# Altium schematic sheet wls2-norflash.SchDoc(wls2-norflash)
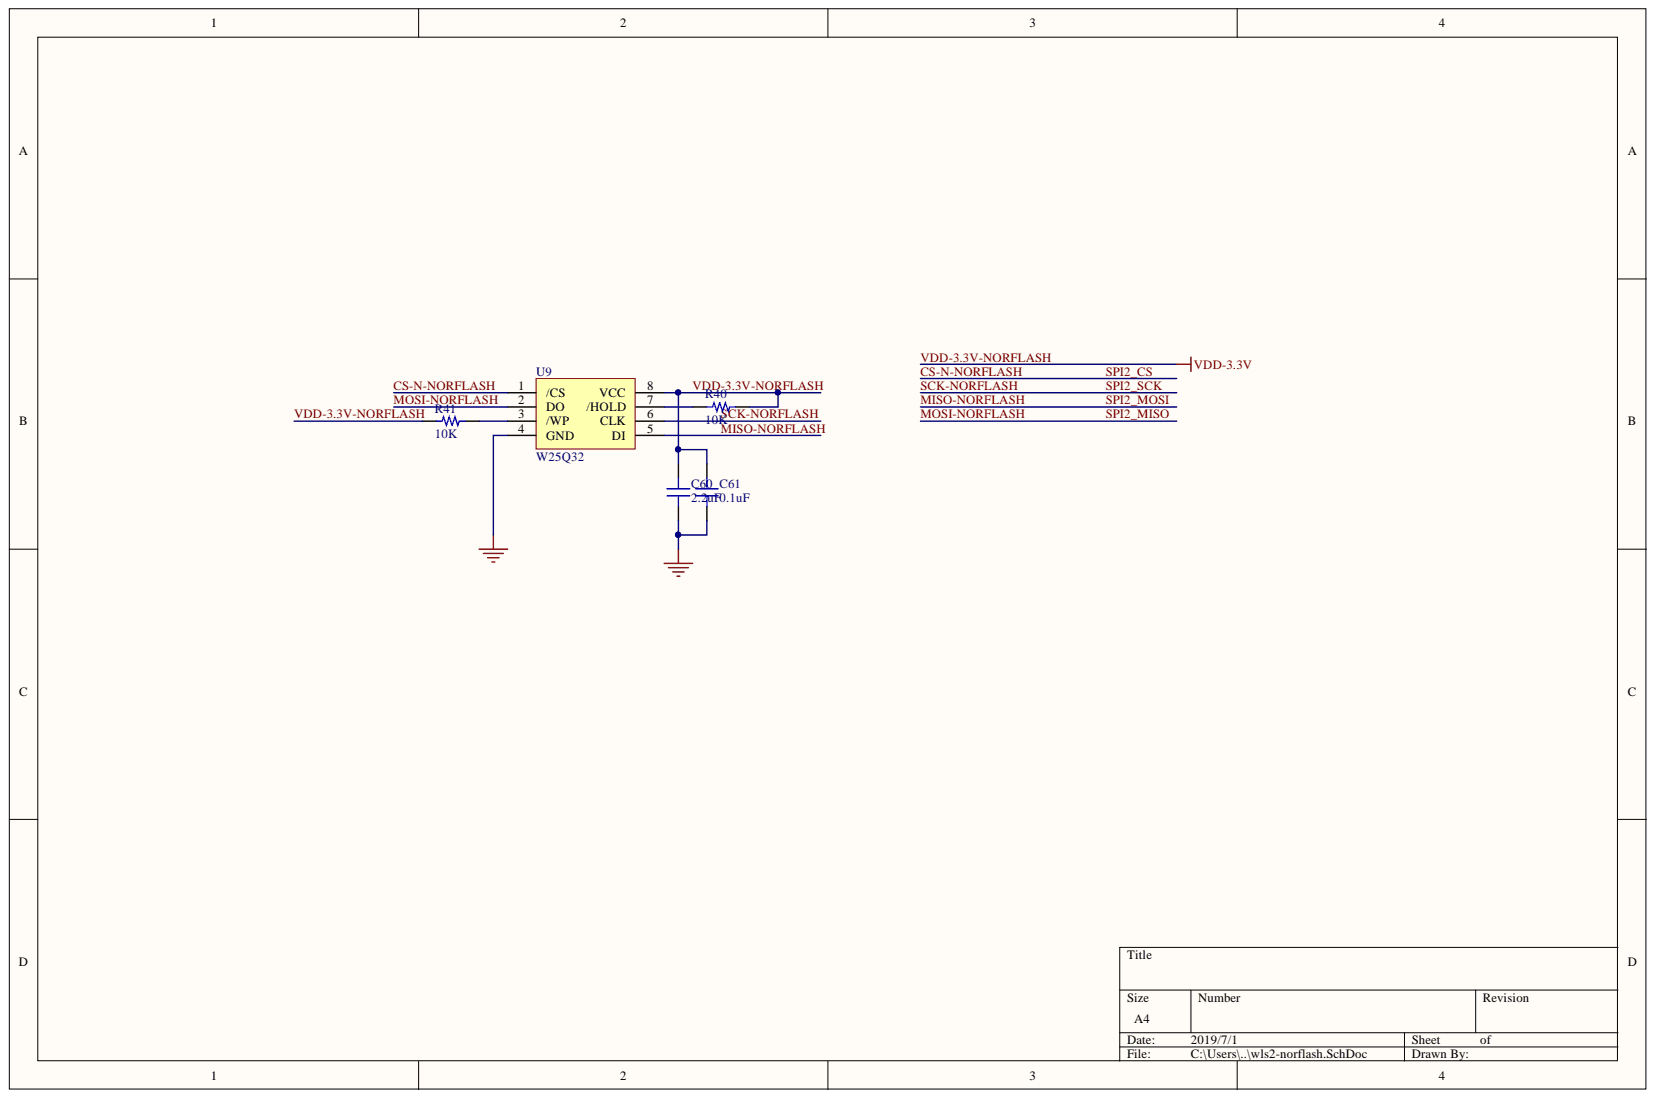
[diagram p0: wls2-norflash.SchDoc]

## Parts
C60  2.2uF  [CAP 0402/1005]
C61  0.1uF  [RES 0402/1005]
R40  10K  [RES 0402/1005]
R41  10K  [RES 0402/1005]
U9  W25Q32  [SOIC127P790X216-8N]

## Nets
GND: C60.1 C61.1 U9.4(GND)
CS-N-NORFLASH: U9.1(/CS)
MISO-NORFLASH: U9.5(DI)
MOSI-NORFLASH: U9.2(DO)
SCK-NORFLASH: U9.6(CLK)
VDD-3.3V-NORFLASH: C60.2 C61.2 R40.2 R41.2 U9.8(VCC)
NetR40_1: R40.1 U9.7(/HOLD)
NetR41_1: R41.1 U9.3(/WP)

## Library data of the parts
C60 C61 = Capacitor: SMT Capacitor; Component Kind: Standard; Library Name: Passives.SchLib
  C60: Value: 2.2uF
  C61: Value: 0.1uF
R40 R41 = Resistor: SMT Resistor; Component Kind: Standard; Library Name: Passives.SchLib; Value: 10K
U9 = W25Q16: Component Kind: Standard; Library Name: Footprint.SchLib
